# Altium schematic sheet P05_LAN9252.SchDoc
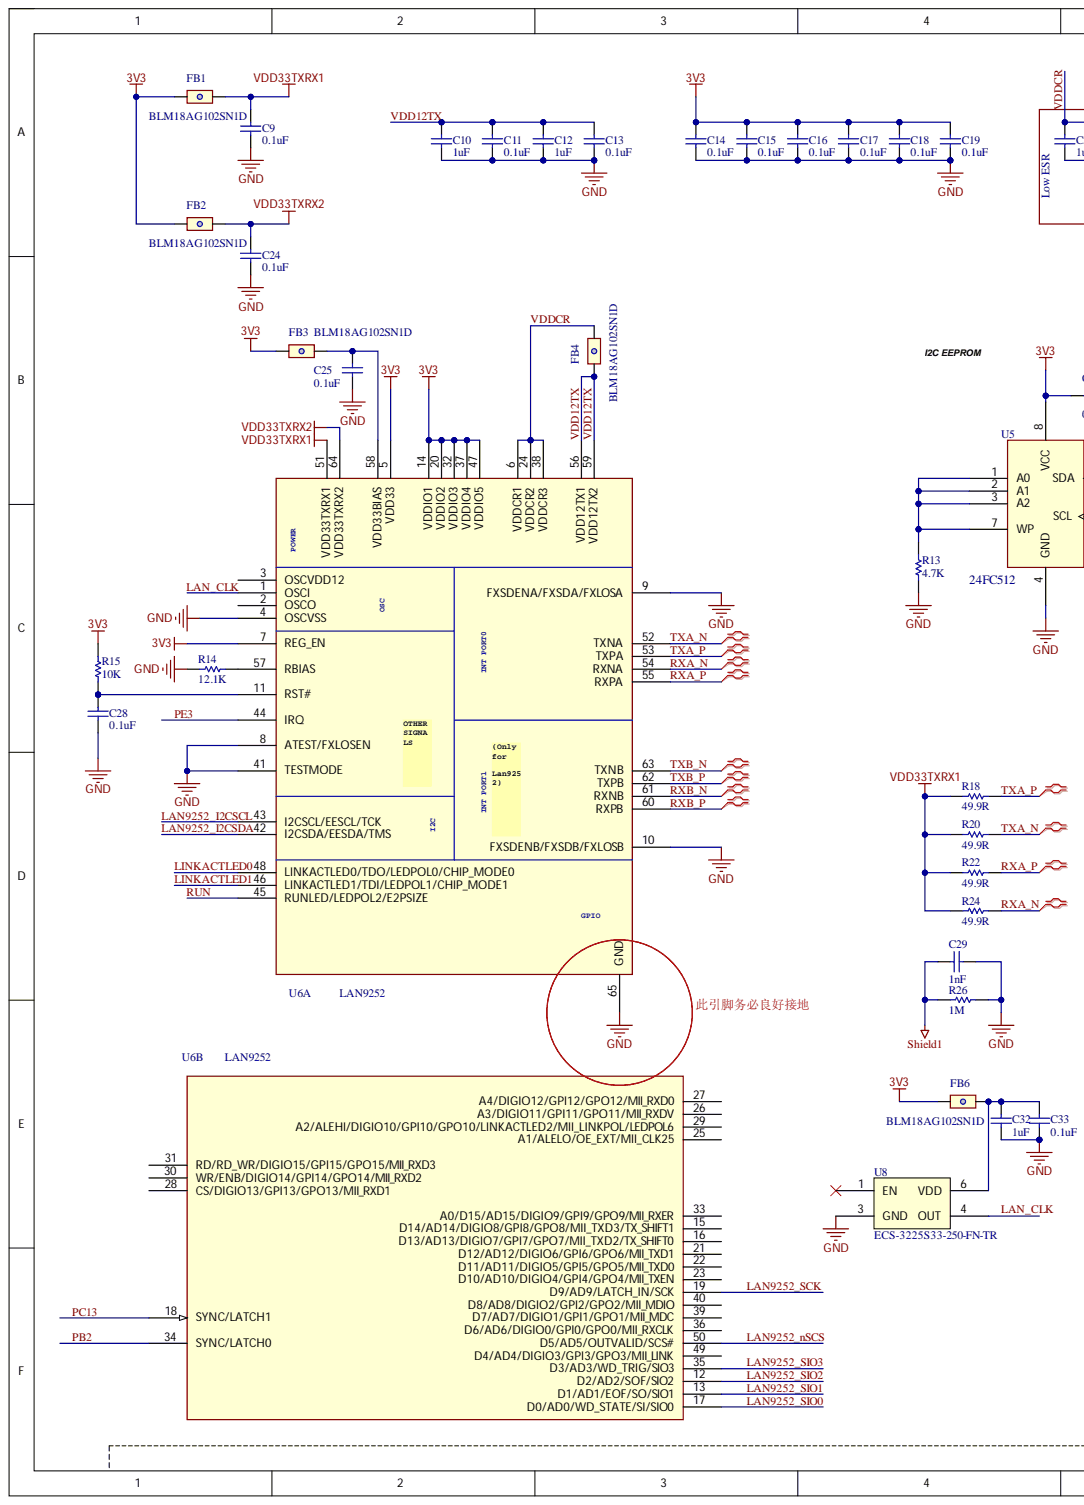
[diagram p0: P05_LAN9252.SchDoc, left]
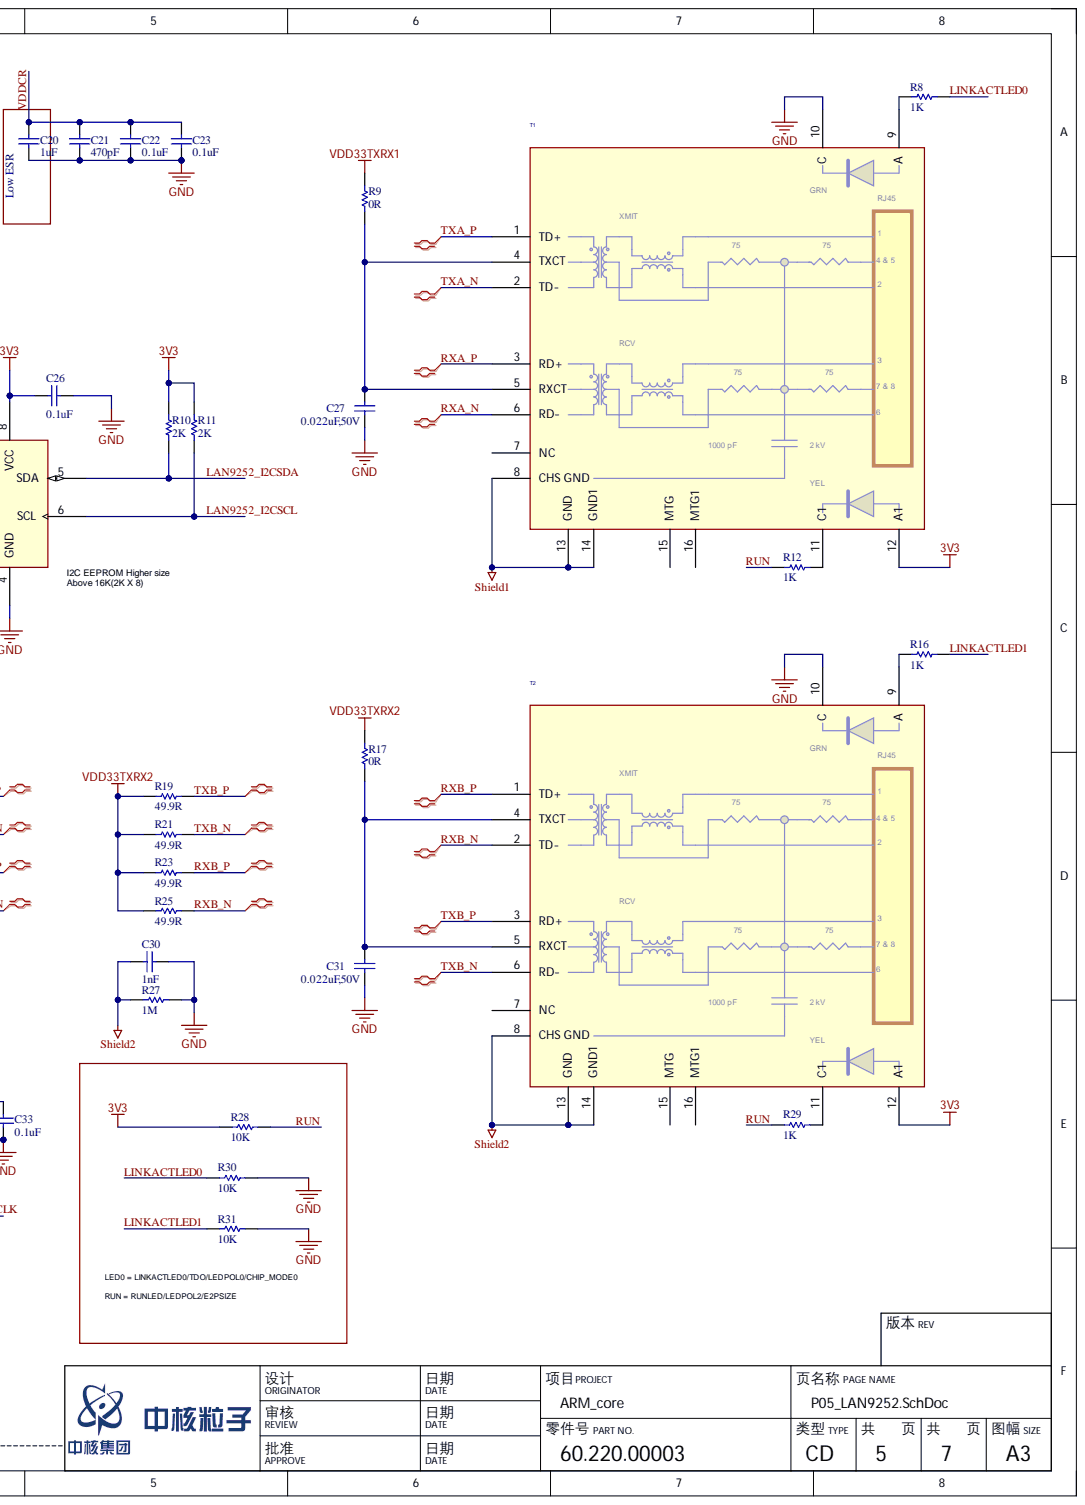
[diagram p1: P05_LAN9252.SchDoc, right]

## Parts
C9  0.1uF  [C0603(1608)]
C10  1uF  [C0603(1608)]
C11  0.1uF  [C0603(1608)]
C12  1uF  [C0603(1608)]
C13  0.1uF  [C0603(1608)]
C14  0.1uF  [C0603(1608)]
C15  0.1uF  [C0603(1608)]
C16  0.1uF  [C0603(1608)]
C17  0.1uF  [C0603(1608)]
C18  0.1uF  [C0603(1608)]
C19  0.1uF  [C0603(1608)]
C20  1uF  [C0603(1608)]
C21  470pF  [C0603(1608)]
C22  0.1uF  [C0603(1608)]
C23  0.1uF  [C0603(1608)]
C24  0.1uF  [C0603(1608)]
C25  0.1uF  [C0603(1608)]
C26  0.1uF  [C0603(1608)]
C27  0.022uF,50V  [C0603(1608)]
C28  0.1uF  [C0603(1608)]
C29  1nF  [C1206(3216)]
C30  1nF  [C1206(3216)]
C31  0.022uF,50V  [C0603(1608)]
C32  1uF  [C0603(1608)]
C33  0.1uF  [C0603(1608)]
FB1  BLM18AG102SN1D  [R0603(1608)]
FB2  BLM18AG102SN1D  [R0603(1608)]
FB3  BLM18AG102SN1D  [R0603(1608)]
FB4  BLM18AG102SN1D  [R0603(1608)]
FB6  BLM18AG102SN1D  [R0603(1608)]
R8  1K  [R0603(1608)]
R9  0R  [R0603(1608)]
R10  2K  [R0603(1608)]
R11  2K  [R0603(1608)]
R12  1K  [R0603(1608)]
R13  4.7K  [R0603(1608)]
R14  12.1K  [R0603(1608)]
R15  10K  [R0603(1608)]
R16  1K  [R0603(1608)]
R17  0R  [R0603(1608)]
R18  49.9R  [R0603(1608)]
R19  49.9R  [R0603(1608)]
R20  49.9R  [R0603(1608)]
R21  49.9R  [R0603(1608)]
R22  49.9R  [R0603(1608)]
R23  49.9R  [R0603(1608)]
R24  49.9R  [R0603(1608)]
R25  49.9R  [R0603(1608)]
R26  1M  [R1206(3216)]
R27  1M  [R1206(3216)]
R28  10K  [R0603(1608)]
R29  1K  [R0603(1608)]
R30  10K  [R0603(1608)]
R31  10K  [R0603(1608)]
T1  J0011D01BNL  [J0011D01BNL]  (value not drawn)
T2  J0011D01BNL  [J0011D01BNL]  (value not drawn)
U5  24FC512  [SOIC8_N]
U6  LAN9252  [LAN9252]  (parts A,B)
U8  ECS-3225S33-250-FN-TR  [ECS-3225S33-250-FN-TR]

## Nets
3V3: C14.2 C15.2 C16.2 C17.2 C18.2 C19.2 C26.1 FB1.1 FB2.1 FB3.1 FB6.1 R10.2 R11.2 R15.2 R28.1 T1.12(A1) T2.12(A1) U5.8(VCC) U6.5(VDD33) U6.7(REG_EN) U6.14(VDDIO1) U6.20(VDDIO2) U6.32(VDDIO3) U6.37(VDDIO4) U6.47(VDDIO5)
GND: C9.1 C10.1 C11.1 C12.1 C13.1 C14.1 C15.1 C16.1 C17.1 C18.1 C19.1 C20.1 C21.1 C22.1 C23.1 C24.1 C25.2 C26.2 C27.1 C28.2 C29.2 C30.2 C31.1 C32.1 C33.1 R13.1 R14.2 R26.2 R27.2 R30.2 R31.2 T1.10(C) T2.10(C) U5.4(GND) U6.4(OSCVSS) U6.8(ATEST/FXLOSEN) U6.9(FXSDENA/FXSDA/FXLOSA) U6.10(FXSDENB/FXSDB/FXLOSB) U6.41(TESTMODE) U6.65(GND) U8.3(GND)
Shield1: C29.1 R26.1 T1.8(CHS_GND) T1.13(GND) T1.14(GND1)
Shield2: C30.1 R27.1 T2.8(CHS_GND) T2.13(GND) T2.14(GND1)
VDD33TXRX1: C9.2 FB1.2 R9.2 R18.1 R20.1 R22.1 R24.1 U6.51(VDD33TXRX1)
VDD33TXRX2: C24.2 FB2.2 R17.2 R19.1 R21.1 R23.1 R25.1 U6.64(VDD33TXRX2)
LAN9252_I2CSCL: R11.1 U5.6(SCL) U6.43(I2CSCL/EESCL/TCK)
LAN9252_I2CSDA: R10.1 U5.5(SDA) U6.42(I2CSDA/EESDA/TMS)
LAN9252_nSCS: U6.50(D5/AD5/OUTVALID/SCS#)
LAN9252_SCK: U6.19(D9/AD9/LATCH_IN/SCK)
LAN9252_SIO0: U6.17(D0/AD0/WD_STATE/SI/SIO0)
LAN9252_SIO1: U6.13(D1/AD1/EOF/SO/SIO1)
LAN9252_SIO2: U6.12(D2/AD2/SOF/SIO2)
LAN9252_SIO3: U6.35(D3/AD3/WD_TRIG/SIO3)
LAN_CLK: U6.1(OSCI) U8.4(OUT)
LINKACTLED0: R8.2 R30.1 U6.48(LINKACTLED0/TDO/LEDPOL0/CHIP_MODE0)
LINKACTLED1: R16.2 R31.1 U6.46(LINKACTLED1/TDI/LEDPOL1/CHIP_MODE1)
PB2: U6.34(SYNC/LATCH0)
PC13: U6.18(SYNC/LATCH1)
PE3: U6.44(IRQ)
RUN: R12.1 R28.2 R29.1 U6.45(RUNLED/LEDPOL2/E2PSIZE)
RXA_N: R24.2 T1.6(RD-) U6.54(RXNA)
RXA_P: R22.2 T1.3(RD+) U6.55(RXPA)
RXB_N: R25.2 T2.2(TD-) U6.61(RXNB)
RXB_P: R23.2 T2.1(TD+) U6.60(RXPB)
TXA_N: R20.2 T1.2(TD-) U6.52(TXNA)
TXA_P: R18.2 T1.1(TD+) U6.53(TXPA)
TXB_N: R21.2 T2.6(RD-) U6.63(TXNB)
TXB_P: R19.2 T2.3(RD+) U6.62(TXPB)
VDD12TX: C10.2 C11.2 C12.2 C13.2 FB4.1 U6.56(VDD12TX1) U6.59(VDD12TX2)
VDDCR: C20.2 C21.2 C22.2 C23.2 FB4.2 U6.6(VDDCR1) U6.24(VDDCR2) U6.38(VDDCR3)
NetC25_1: C25.1 FB3.2 U6.58(VDD33BIAS)
NetC27_2: C27.2 R9.1 T1.4(TXCT) T1.5(RXCT)
NetC28_1: C28.1 R15.1 U6.11(RST#)
NetC31_2: C31.2 R17.1 T2.4(TXCT) T2.5(RXCT)
NetC32_2: C32.2 C33.2 FB6.2 U8.6(VDD)
NetR8_1: R8.1 T1.9(A)
NetR12_2: R12.2 T1.11(C1)
NetR13_2: R13.2 U5.1(A0) U5.2(A1) U5.3(A2) U5.7(WP)
NetR14_1: R14.1 U6.57(RBIAS)
NetR16_1: R16.1 T2.9(A)
NetR29_2: R29.2 T2.11(C1)

Not connected: T1 7(NC) 15(MTG) 16(MTG1); T2 7(NC) 15(MTG) 16(MTG1); U6 2(OSCO) 3(OSCVDD12) 15(D14/AD14/DIGIO8/GPI8/GPO8/MII_TXD3/TX_SHIFT1) 16(D13/AD13/DIGIO7/GPI7/GPO7/MII_TXD2/TX_SHIFT0) 21(D12/AD12/DIGIO6/GPI6/GPO6/MII_TXD1) 22(D11/AD11/DIGIO5/GPI5/GPO5/MII_TXD0) 23(D10/AD10/DIGIO4/GPI4/GPO4/MII_TXEN) 25(A1/ALELO/OE_EXT/MII_CLK25) 26(A3/DIGIO11/GPI11/GPO11/MII_RXDV) 27(A4/DIGIO12/GPI12/GPO12/MII_RXD0) 28(CS/DIGIO13/GPI13/GPO13/MII_RXD1) 29(A2/ALEHI/DIGIO10/GPI10/GPO10/LINKACTLED2/MII_LINKPOL/LEDPOL6) 30(WR/ENB/DIGIO14/GPI14/GPO14/MII_RXD2) 31(RD/RD_WR/DIGIO15/GPI15/GPO15/MII_RXD3) 33(A0/D15/AD15/DIGIO9/GPI9/GPO9/MII_RXER) 36(D6/AD6/DIGIO0/GPI0/GPO0/MII_RXCLK) 39(D7/AD7/DIGIO1/GPI1/GPO1/MII_MDC) 40(D8/AD8/DIGIO2/GPI2/GPO2/MII_MDIO) 49(D4/AD4/DIGIO3/GPI3/GPO3/MII_LINK); U8 1(EN)

## Library data of the parts
C9 C11 C13-C19 C22-C25 C28 = Cap 0.1uF 0603: ceramic 25V; 价格: ￥0.092@100; 制造商: KEMET; 型号: C0603C104K3RACTU; Component Kind: Standard; Library Name: RCL.SchLib; 供应商及库存编号: e络盟 1650835
C10 C12 C32 = Cap 1uF 0603-1: ceramic 10V; 价格: ¥0.11@100; 制造商: KEMET; 型号: C0603C105K8PACTU; Component Kind: Standard; Library Name: RCL.SchLib; 供应商及库存编号: e络盟 9227776
C20 = Cap 1uF 0603-1: ceramic 10V; 价格: ￥0.11@100; 制造商: KEMET; 型号: C0603C105K8PACTU; Component Kind: Standard; Library Name: RCL.SchLib; 供应商及库存编号: e络盟 9227776
C21 = Cap 470pF 0603-10V: ceramic 10V; 价格: ￥1.576@10; 制造商: KEMET; 型号: C0603C471K8RAC7867; Component Kind: Standard; Library Name: RCL.SchLib; 供应商及库存编号: Digikey：399-15407-1-ND
C26 C33 = Cap 0.1uF 0603: ceramic 25V; 价格: ¥0.092@100; 制造商: KEMET; 型号: C0603C104K3RACTU; Component Kind: Standard; Library Name: RCL.SchLib; 供应商及库存编号: e络盟 1650835
C27 C31 = Cap 0.022uF 0603-50V: ceramic 50V; 价格: ¥0.13@150; 制造商: YAGEO; 型号: CC0603KRX7R9BB223; Component Kind: Standard; Library Name: RCL.SchLib; 供应商及库存编号: e络盟 3019755RL
C29 C30 = Cap 1nF 1206-2KV: ceramic 2KV; 价格: ¥0.504@100; 制造商: MULTICOMP; 型号: MC1206B102K202CT; Component Kind: Standard; Library Name: RCL.SchLib; 供应商及库存编号: e络盟  1855909
FB1-FB4 = FB-1K@100MHz: 0603; 价格: ￥0.25@100; 制造商: MURATA; Component Kind: Standard; Library Name: RCL.SchLib; 供应商及库存编号: e络盟 1515671; 型号: BLM18AG102SN1D
FB6 = FB-1K@100MHz: 0603; 价格: ¥0.25@100; 制造商: MURATA; Component Kind: Standard; Library Name: RCL.SchLib; 供应商及库存编号: e络盟 1515671; 型号: BLM18AG102SN1D
R8 R12 R16 R29 = Res 1K 0603: Res ±1%; Component Kind: Standard; Library Name: RCL.SchLib
R9 R17 = Res 0R 0603: Res ±1%; Component Kind: Standard; Library Name: RCL.SchLib
R10 R11 = Res 2K 0603: Res ±1%; Component Kind: Standard; Library Name: RCL.SchLib
R13 = Res 4.7K 0603: Res ±1%; Component Kind: Standard; Library Name: RCL.SchLib
R14 = Res 12.1K 0603: Res ±1%; Component Kind: Standard; Library Name: RCL.SchLib
R15 R28 R30 R31 = Res 10K 0603: Res ±1%; Component Kind: Standard; Library Name: RCL.SchLib
R18-R25 = RES0603: R0603; Component Kind: Standard; Library Name: Local IC.SchLib
R26 R27 = Res 1M 1206: Res ±1%; Component Kind: Standard; Library Name: RCL.SchLib
T1 T2 = J0011D01BNL: MAGJACK 1PORT 100 BASE-TX; 价格: $6.27@100; 制造商: Pulse Electronics Network; Component Kind: Standard; Library Name: Connector.SchLib; 供应商及库存编号: digikey 553-1483-ND; 型号: J0011D01BNL
U5 = 24FC512: EEPROM, I2C, 512 Kbit, 1 MHz, 8 引脚; 价格: ￥9.05@10; 制造商: MICROCHIP; 型号: 24FC512-I/SM; Component Kind: Standard; Library Name: IC.SchLib; 供应商及库存编号: e络盟 1439744
U6 = LAN9252: ETHERCAT CTLR 10/100 64TQFP; 价格: $10.329@100; 制造商: Microchip Technology; 型号: LAN9252I/PT; Component Kind: Standard; Library Name: IC.SchLib; 供应商及库存编号: digikey LAN9252I/PT-ND
U8 = ECS-3225S33-250-FN-TR: OSC XO 25.0000MHZ HCMOS SMD; 价格: $0.755@1K; 制造商: ECS Inc.; Component Kind: Standard; Library Name: IC.SchLib; 供应商及库存编号: digikey XC2221TR-ND; 型号: ECS-3225S33-250-FN-TR
